# Altium schematic sheet 4. Flash + Debug.SchDoc("4. Flash + Debug")
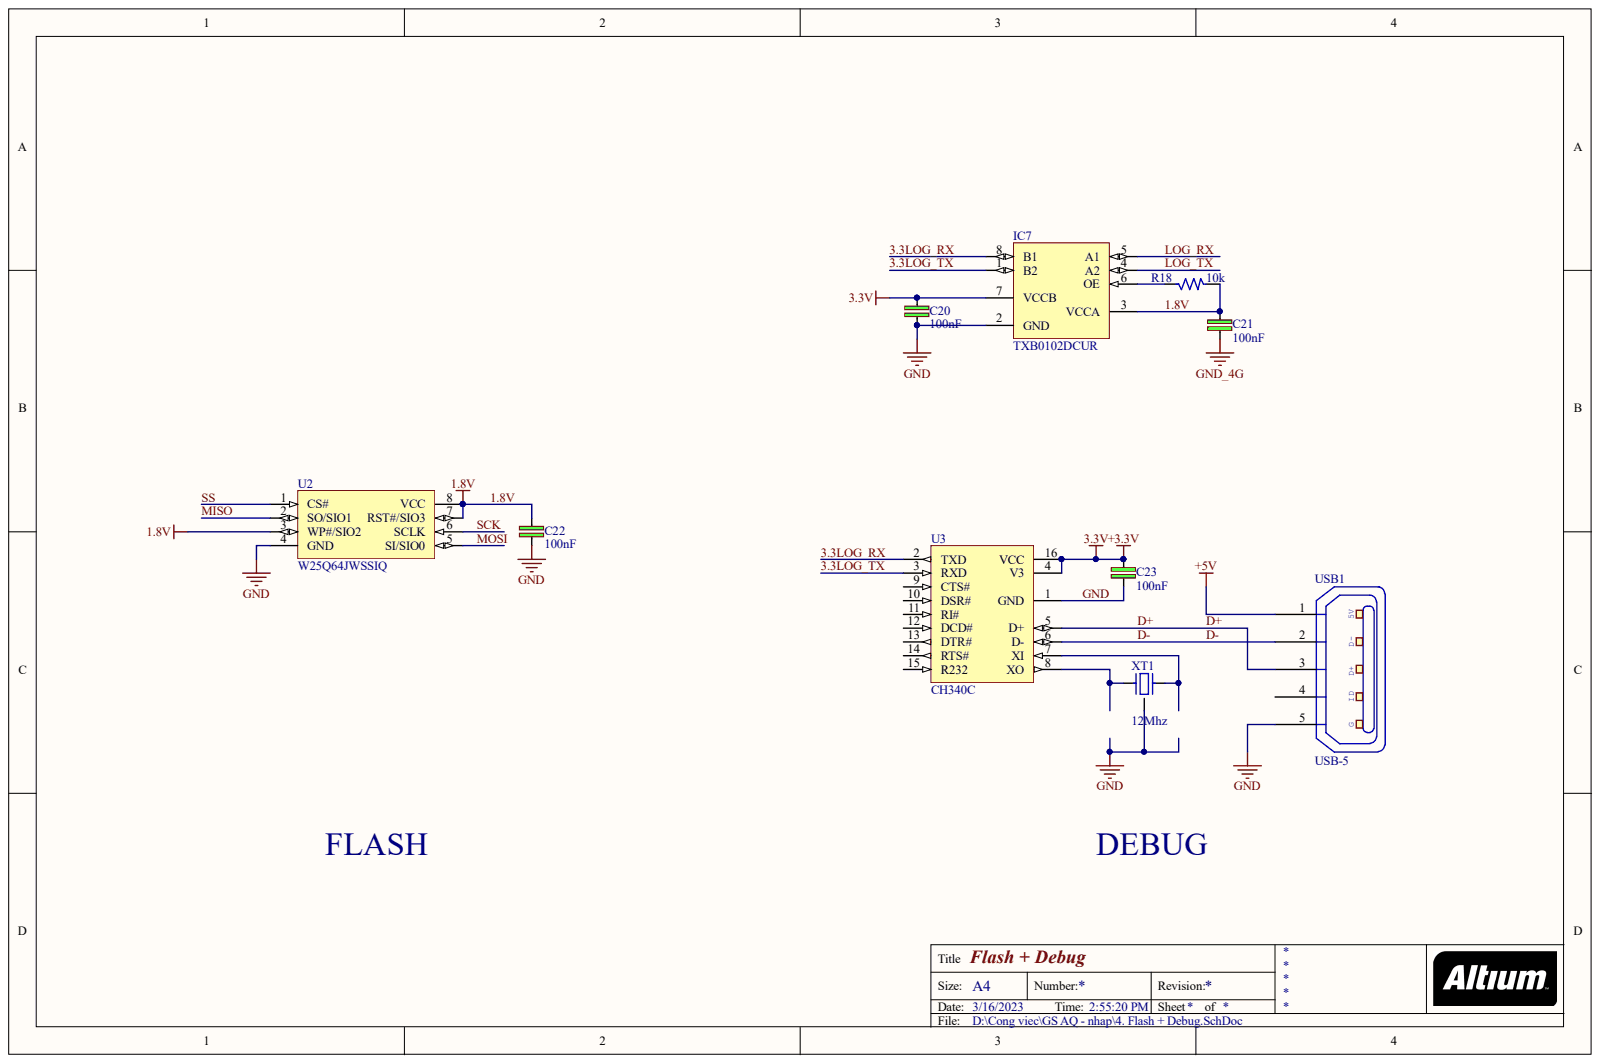
[diagram p0: 4. Flash + Debug.SchDoc]

## Parts
C20  100nF  [C-0805]
C21  100nF  [C-0805]
C22  100nF  [C-0805]
C23  100nF  [C-0805]
IC7  TXB0102DCUR  [US8]
R18  10k  [R-0805]
U2  W25Q64JWSSIQ  [SO-8N]
U3  CH340C  [SOIC-16]
USB1  USB-5  [USB-M1]
XT1  12Mhz  [XT32-3]

## Nets
+3.3V: C20.1 C23.2 IC7.7(VCCB) U3.4(V3) U3.16(VCC)
+5V: USB1.1
GND_4G: C21.2
1.8V: C21.1 C22.2 IC7.3(VCCA) R18.2 U2.3(WP#/SIO2) U2.7(RST#/SIO3) U2.8(VCC)
3.3LOG_RX: IC7.8(B1) U3.2(TXD)
3.3LOG_TX: IC7.1(B2) U3.3(RXD)
D+: U3.5(D+) USB1.3
D-: U3.6(D-) USB1.2
GND: C20.2 C22.1 C23.1 IC7.2(GND) U2.4(GND) U3.1(GND) USB1.5 XT1.3
LOG_RX: IC7.5(A1)
LOG_TX: IC7.4(A2)
MISO: U2.2(SO/SIO1)
MOSI: U2.5(SI/SIO0)
SCK: U2.6(SCLK)
SS: U2.1(CS#)
NetIC7_6: IC7.6(OE) R18.1
NetU3_7: U3.7(XI) XT1.2
NetU3_8: U3.8(XO) XT1.1

Not connected: U3 9(CTS#) 10(DSR#) 11(RI#) 12(DCD#) 13(DTR#) 14(RTS#) 15(R232); USB1 4

## Library data of the parts
C20-C23 = C: Cap SMD; Manufacturer: Samsung Electro-Mechanics; Part number: CL21B104KBCNNWC; User_Description: CAP CER 0.1UF 50V X7R 0805; Category: CAPACITOR; Component Kind: Standard; Package: 0805; Value: 100nF; Library Name: Anh_Trung.SchLib
IC7 = TXB0102DCUR: IC TRNSLTR BIDIRECTIONAL US8; Manufacturer: Texas Instruments; Category: IC Logic Level; Component Kind: Standard; Package: 8-VSSOP; Part number: TXB0102DCUR; User_Description: IC TRNSLTR BIDIRECTIONAL US8; Library Name: Anh_Trung.SchLib
R18 = R: Resistor 1/4W; Manufacturer: Yageo; Part number: RC0805FR-0710KL; User_Description: RES SMD 10K OHM 1% 1/8W 0805; Category: RESISTORS; Component Kind: Standard; Package: 0805; Value: 10k; Library Name: Anh_Trung.SchLib
U2 = W25Q128JVSIQ: IC FLASH 128MBIT SPI/QUAD 8SOIC; Manufacturer: Winbond Electronics; Category: Memory; Component Kind: Standard; Package: 8-SOIC; Part number: W25Q64JWSSIQ; PCB3D: DIP8; User_Description: IC FLASH 128MBIT SPI/QUAD 8SOIC; Library Name: Anh_Trung.SchLib
U3 = CH340G: USB to UART Interface; Manufacturer: WCH; Category: IC UART Interface; Component Kind: Standard; Package: SOIC-16; Part number: CH340G; User_Description: USB to UART Interface; Library Name: Anh_Trung.SchLib
USB1 = USB-5: Manufacturer: FCI; Category: Connectors, Interconnects; Component Kind: Standard; Library Name: Anh_Trung.SchLib
XT1 = XT32k: Crystal 32,768kHz for timer real; Component Kind: Standard; Value: 32,768khz; Library Name: Anh_Trung.SchLib
